# Altium schematic sheet nanoCH57x.SchDoc
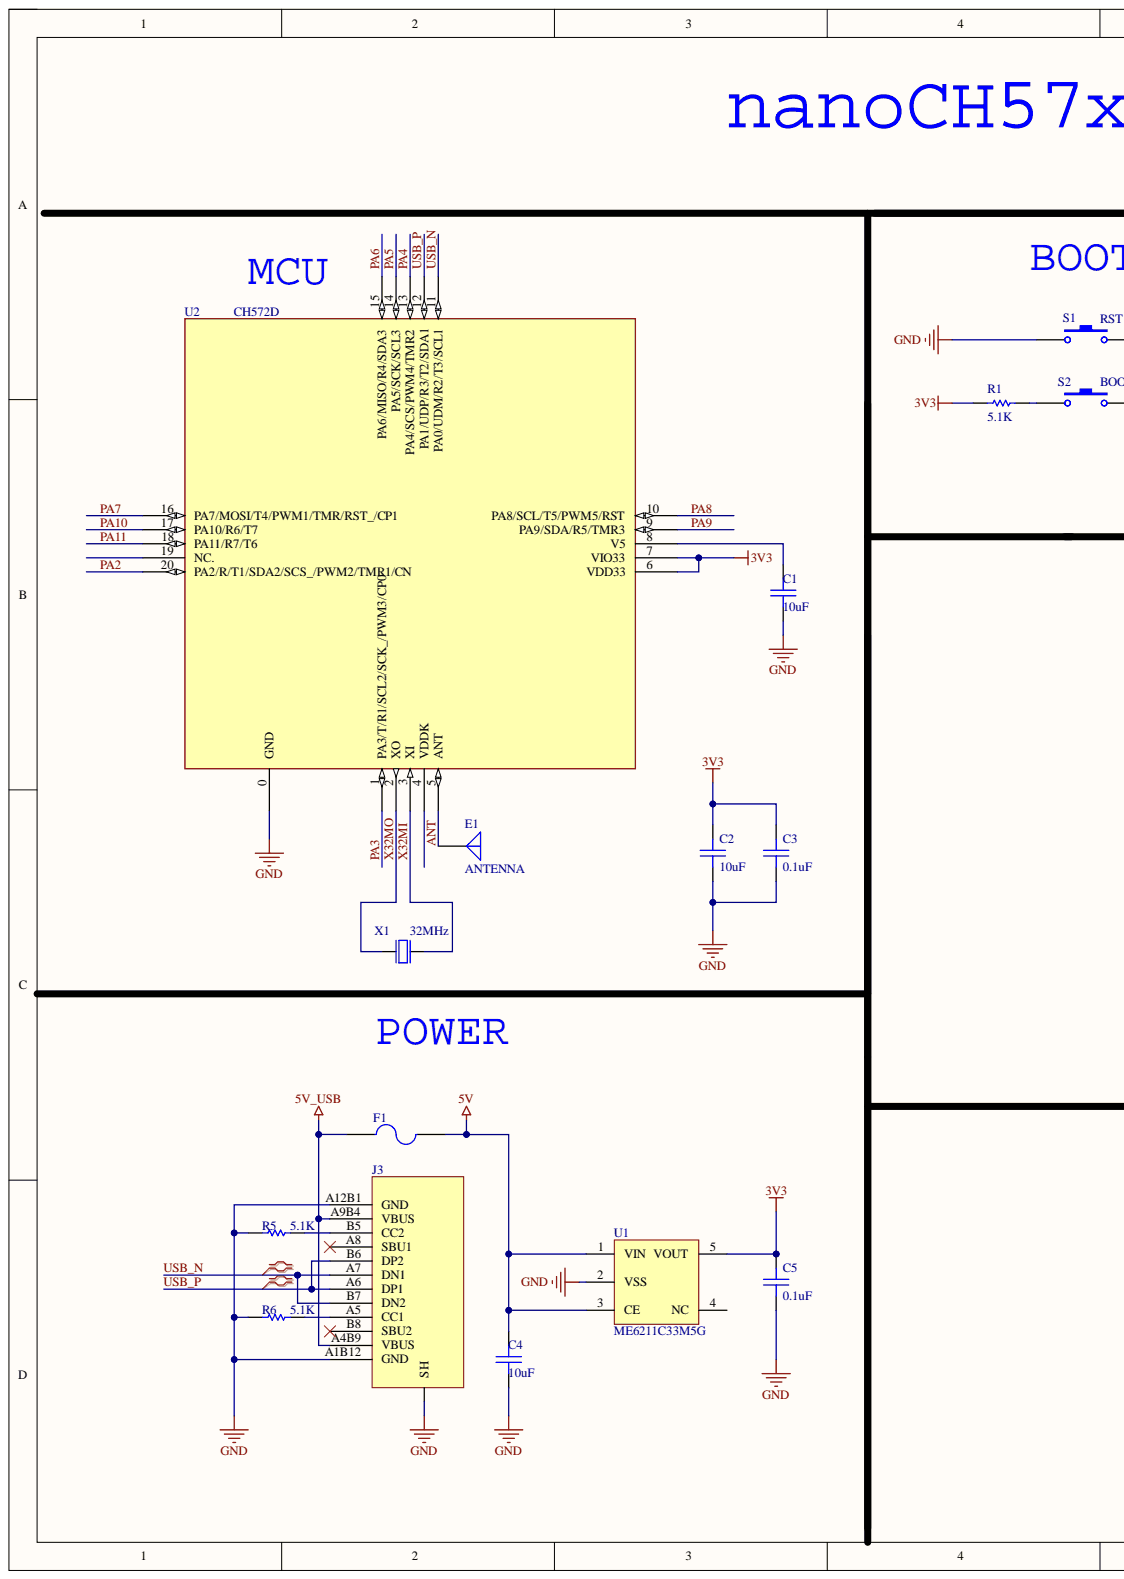
[diagram p0: nanoCH57x.SchDoc, left]
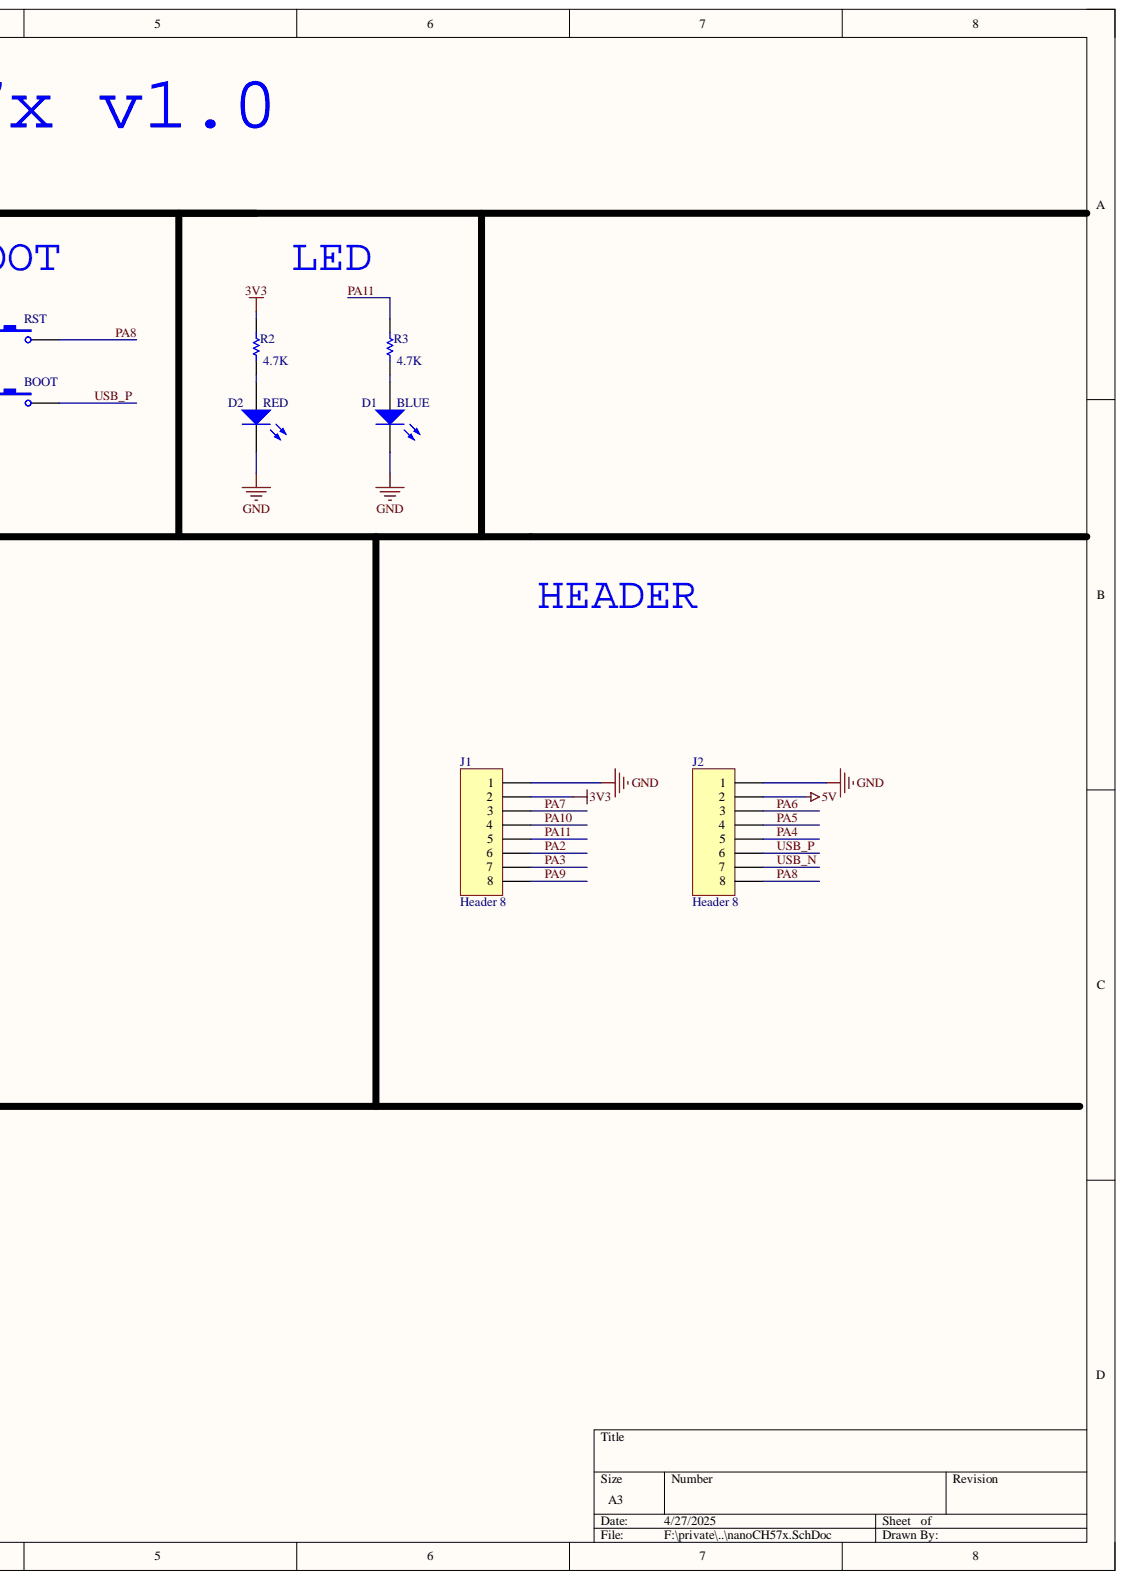
[diagram p1: nanoCH57x.SchDoc, right]

## Parts
C1  10uF  [C 0402_M_new]
C2  10uF  [C 0402_M_new]
C3  0.1uF  [C 0402_M_new]
C4  10uF  [C 0402_M_new]
C5  0.1uF  [C 0402_M_new]
D1  BLUE  [0603_LED_B]
D2  RED  [0603_LED_B]
E1  ANTENNA  [ANT-F-1-2.4G-1.6mmFR4]
F1  Fuse 2  [FU 0805]  (value not drawn)
J1  Header 8  [HDR2.54-LI-8P]
J2  Header 8  [HDR2.54-LI-8P]
J3  Type_C_16P  [Type_C_16P]  (value not drawn)
R1  5.1K  [R 0402_M_new]
R2  4.7K  [R 0402_M_new]
R3  4.7K  [R 0402_M_new]
R5  5.1K  [R 0402_M_new]
R6  5.1K  [R 0402_M_new]
S1  RST  [SW-SMD_4P-L4.2-W3.3-P2.15-LS5.1]
S2  BOOT  [SW-SMD_4P-L4.2-W3.3-P2.15-LS5.1]
U1  ME6211C33M5G  [SOT23-5]
U2  CH572D  [QFN20_3X3]
X1  32MHz  [BT-XTAL_3225]

## Nets
3V3: C2.1 C3.1 C5.1 J1.2 R1.1 R2.1 U1.5(VOUT) U2.6(VDD33) U2.7(VIO33)
5V: C4.1 F1.2 J2.2 U1.1(VIN) U1.3(CE)
5V_USB: F1.1 J3.A4B9(VBUS) J3.A9B4(VBUS)
GND: C1.2 C2.2 C3.2 C4.2 C5.2 D1.2 D2.2 J1.1 J2.1 J3.0(SH) J3.A1B12(GND) J3.A12B1(GND) R5.1 R6.1 S1.1 U1.2(VSS) U2.0(GND) X1.2 X1.4
ANT: E1.1 U2.5(ANT)
PA2: J1.6 U2.20(PA2/R/T1/SDA2/SCS_/PWM2/TMR1/CN)
PA3: J1.7 U2.1(PA3/T/R1/SCL2/SCK_/PWM3/CP0)
PA4: J2.5 U2.13(PA4/SCS/PWM4/TMR2)
PA5: J2.4 U2.14(PA5/SCK/SCL3)
PA6: J2.3 U2.15(PA6/MISO/R4/SDA3)
PA7: J1.3 U2.16(PA7/MOSI/T4/PWM1/TMR/RST_/CP1)
PA8: J2.8 S1.2 U2.10(PA8/SCL/T5/PWM5/RST)
PA9: J1.8 U2.9(PA9/SDA/R5/TMR3)
PA10: J1.4 U2.17(PA10/R6/T7)
PA11: J1.5 R3.1 U2.18(PA11/R7/T6)
USB_N: J2.7 J3.A7(DN1) J3.B7(DN2) U2.11(PA0/UDM/R2/T3/SCL1)
USB_P: J2.6 J3.A6(DP1) J3.B6(DP2) S2.2 U2.12(PA1/UDP/R3/T2/SDA1)
X32MI: U2.3(XI) X1.3
X32MO: U2.2(XO) X1.1
NetC1_1: C1.1 U2.8(V5)
NetD1_1: D1.1 R3.2
NetD2_1: D2.1 R2.2
NetJ3_A5: J3.A5(CC1) R6.2
NetJ3_B5: J3.B5(CC2) R5.2
NetR1_2: R1.2 S2.1

Not connected: J3 A8(SBU1) B8(SBU2); U1 4(NC); U2 4(VDDK) 19(NC.)

## Library data of the parts
C1 C4 = SMT_C_0805: Surface mount capacitor 0805; Component Kind: Standard; Library Name: Vowstar_Basic_Device.IntLib
C2 C3 C5 = SMT_C_0402: Surface mount capacitor 0402; Component Kind: Standard; Library Name: Vowstar_Basic_Device.IntLib
D1 D2 = D0603: Typical RED, GREEN, YELLOW, AMBER GaAs LED; Component Kind: Standard; LatestRevisionDate: 17-Jul-2002; LatestRevisionNote: Re-released for DXP Platform.; Library Name: MYLIB.IntLib; PackageDescription: SM LED; 2 Flat Leads; PackageReference: 3.2X1.6X1.1; PackageVersion: Feb-2002; Published: 8-Jun-2000; Simulation: LED2; Publisher: Altium Limited
E1 = ANTENNA: Generic Antenna; Component Kind: Standard
F1 = Fuse 2: Fuse; Component Kind: Standard; LatestRevisionDate: 17-Jul-2002; LatestRevisionNote: Re-released for DXP Platform.; Library Name: Miscellaneous Devices.IntLib; PackageDescription: Thru-Hole; 2 Leads; PackageReference: PIN-W2/E2.8; Published: 8-Jun-2000; Simulation: FUSE; Publisher: Altium Limited
R1-R3 R5 R6 = R0603: Resistor; Component Kind: Standard; LatestRevisionDate: 17-Jul-2002; LatestRevisionNote: Re-released for DXP Platform.; Library Name: MYLIB.IntLib; PackageDescription: Axial Device, Thru-Hole; 2 Leads; 0.3 in Pin Spacing; PackageReference: AXIAL-0.3; Published: 8-Jun-2000; Signal Integrity: Res; Simulation: RESISTOR; Publisher: Altium Limited
  R5 R6: Value: 5.1K
S1 S2 = SW-PB: Switch; Component Kind: Standard; LatestRevisionDate: 17-Jul-2002; LatestRevisionNote: Re-released for DXP Platform.; Library Name: Miscellaneous Devices.IntLib; PackageDescription: Switch; 2 Leads; PackageReference: SPST-2; Published: 24-Mar-1999; Publisher: Altium Limited
U2 = CH572D: RISC-V MCU with BLE/2.4GHz Wireless/USB/SPI/UART/I2C/PWM/CMP; Component Kind: Standard; Library Name: nanoCH57x.SCHLIB
X1 = XTAL SMD 12MHz (3225): XTAL SMD 3225, 8MHz, 18pF, ±30ppm; 2nd source part number: 184-2056; Manufacturer: TXC Corporation; Manufacturer Part Number: 7M-12.000MAAJ-T; Artikkelnr Noca: 22019166; Artikkelnr Simpro: N.A.; Database Table Name: Crystal_Osc$; Note: XTAL SMD 12.000MHz; 2nd source Supplier: Farnell; Component Kind: Standard; Datasheet: http://www.txccrystal.com/images/pdf/7m.pdf; Footprint Doc: BT-XTAL_3225; LatestRevisionDate: 2010-08-31; Library Name: Nordic Discrete DB.DbLib; Part Number: XTAL SMD 12MHz (3225); Pin Count: 4; Published: 2010-08-31; Supplier: Digi-Key; Supplier part number: 887-1121-1-ND; Value: 8MHz; Publisher: Nordic Semiconductor
J1 J2 = Header 8: Component Kind: Standard; Library Name: nanoCH57x.SCHLIB
J3 = Type_C_16P: Component Kind: Standard; Library Name: ICESugar.SCHLIB
U1 = ME6211C33M5G: Component Kind: Standard
